# Altium schematic sheet Power.SchDoc(Power)
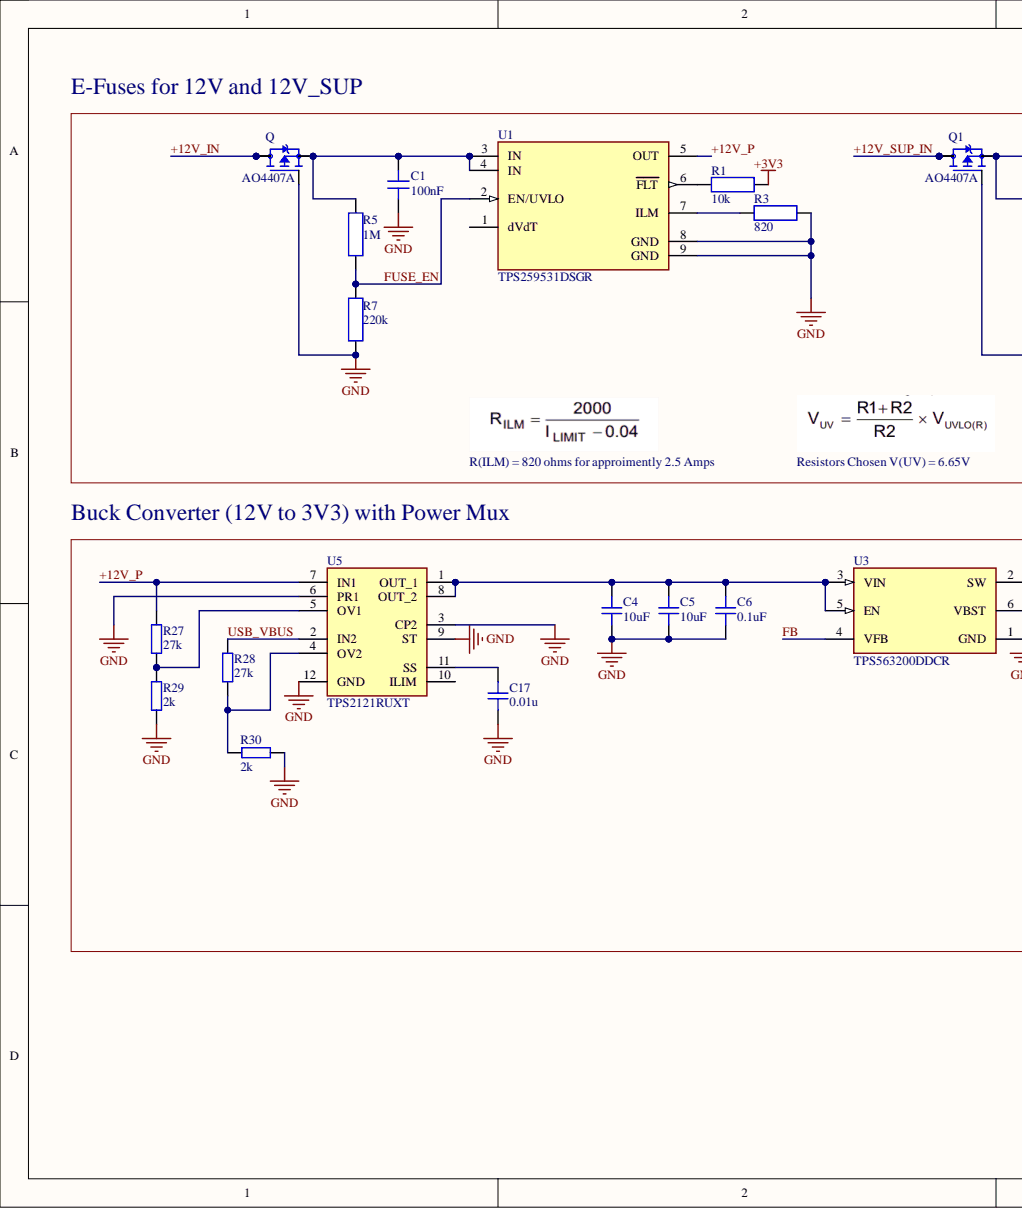
[diagram p0: Power.SchDoc, left]
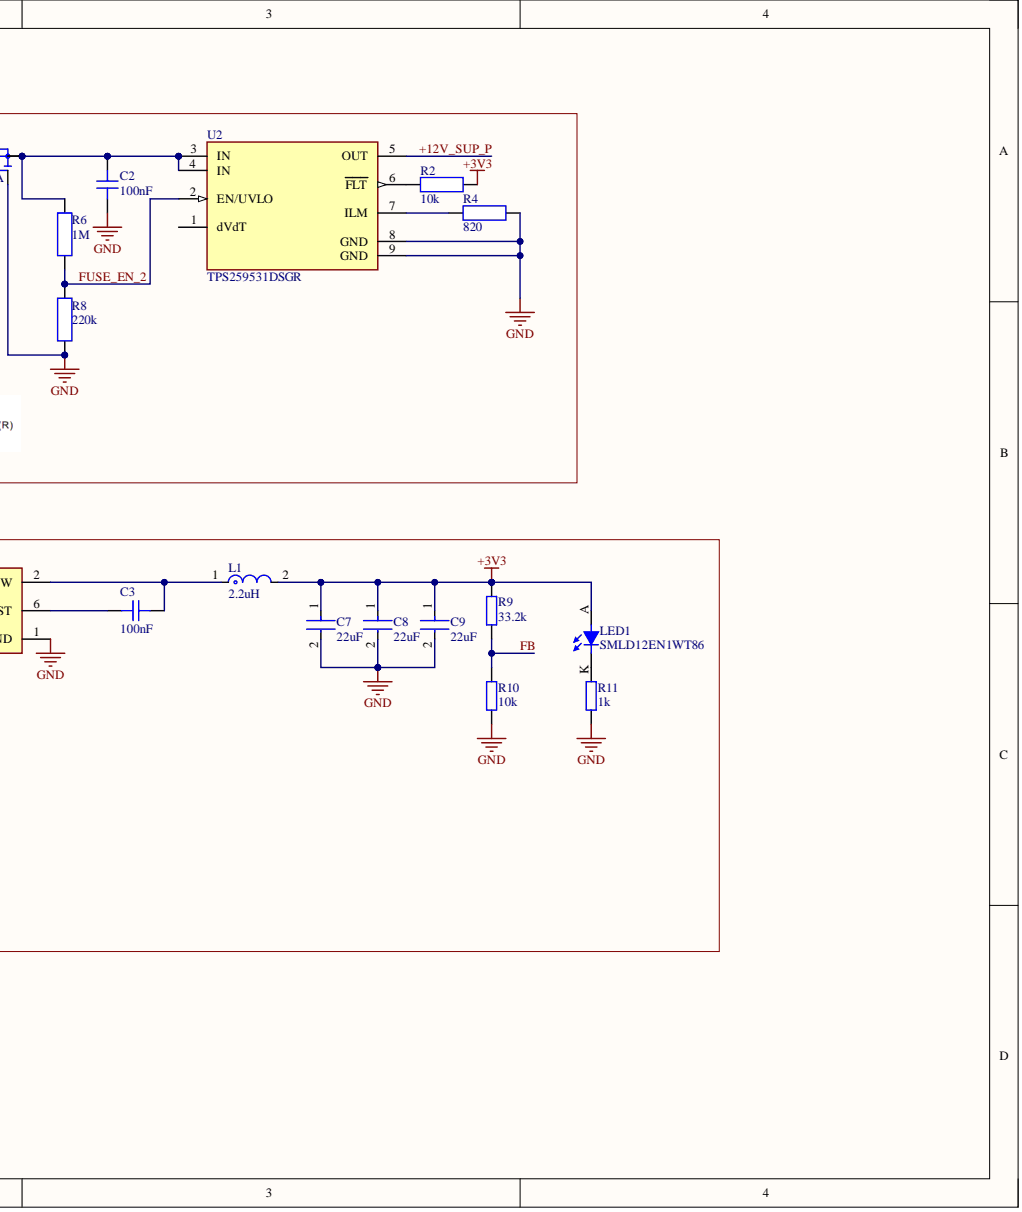
[diagram p1: Power.SchDoc, right]

## Parts
C1  value 100nF  (hidden comment: C0603C104K5RACTU)
C2  value 100nF  (hidden comment: C0603C104K5RACTU)
C3  100nF
C4  10uF
C5  10uF
C6  0.1uF
C7  22uF
C8  22uF
C9  22uF
C17  0.01u
L1  2.2uH
LED1  SMLD12EN1WT86
Q  AO4407A
Q1  AO4407A
R1  value 10k  (hidden comment: GENERIC_____0603)
R2  value 10k  (hidden comment: GENERIC_____0603)
R3  value 820  (hidden comment: GENERIC_____0603)
R4  value 820  (hidden comment: GENERIC_____0603)
R5  value 1M  (hidden comment: GENERIC_____0603)
R6  value 1M  (hidden comment: GENERIC_____0603)
R7  value 220k  (hidden comment: GENERIC_____0603)
R8  value 220k  (hidden comment: GENERIC_____0603)
R9  33.2k
R10  10k
R11  1k
R27  27k
R28  27k
R29  2k
R30  2k
U1  TPS259531DSGR
U2  TPS259531DSGR
U3  TPS563200DDCR
U5  TPS2121RUXT

## Nets
+3V3: C7.1 C8.1 C9.1 L1.2 LED1.A R1.1 R2.1 R9.2
GND: C1.1 C2.1 C4.1 C5.1 C6.1 C7.2 C8.2 C9.2 C17.2 Q.4 Q1.4 R3.2 R4.2 R7.1 R8.1 R10.1 R11.1 R29.1 R30.1 U1.8(GND) U1.9(GND) U2.8(GND) U2.9(GND) U3.1(GND) U5.3(CP2) U5.6(PR1) U5.9(ST) U5.12(GND)
+12V_IN: Q.5 Q.6 Q.7 Q.8
+12V_P: R27.2 U1.5(OUT) U5.7(IN1)
+12V_SUP_IN: Q1.5 Q1.6 Q1.7 Q1.8
+12V_SUP_P: U2.5(OUT)
FB: R9.1 R10.2 U3.4(VFB)
FUSE_EN: R5.1 R7.2 U1.2(EN/UVLO)
FUSE_EN_2: R6.1 R8.2 U2.2(EN/UVLO)
USB_VBUS: R28.2 U5.2(IN2)
NetC1_2: C1.2 Q.1 Q.2 Q.3 R5.2 U1.3(IN) U1.4(IN)
NetC2_2: C2.2 Q1.1 Q1.2 Q1.3 R6.2 U2.3(IN) U2.4(IN)
NetC3_1: C3.1 L1.1 U3.2(SW)
NetC3_2: C3.2 U3.6(VBST)
NetC4_2: C4.2 C5.2 C6.2 U3.3(VIN) U3.5(EN) U5.1(OUT_1) U5.8(OUT_2)
NetC17_1: C17.1 U5.11(SS)
NetLED1_K: LED1.K R11.2
NetR1_2: R1.2 U1.6(FLT)
NetR2_2: R2.2 U2.6(FLT)
NetR3_1: R3.1 U1.7(ILM)
NetR4_1: R4.1 U2.7(ILM)
NetR27_1: R27.1 R29.2 U5.5(OV1)
NetR28_1: R28.1 R30.2 U5.4(OV2)

Not connected: U1 1(dVdT); U2 1(dVdT); U5 10(ILIM)

## Library data of the parts
C1 C2 = CMP-001-00097-2: 0.1μF 50V X7R 0603 MLCC; Capacitor Type: Ceramic; Tolerance: 10%; Case/Package: 0603; Component Kind: Standard; Max Operating Temperature: 125°C; Min Operating Temperature: -55°C; Mounting Technology: Surface Mount; Pins: 2; RoHS Compliant: Yes; Voltage Rating: 50V; Library Name: Solar Gators
C3-C6 C17 = CAP_0603: Capacitor SMD 0603; Component Kind: Standard; Library Name: Schematic-Lib-PhilsLab (1).SchLib
C7-C9 = CMP-08246-004858-1: Multilayer Ceramic Capacitors 22μF ±20% 6.3V X5R SMD 1206; Capacitance: 22uF; Case Code (Imperial): 1206; Case Code (Metric): 3216; Case/Package: 1206; Component Kind: Standard; Depth: 1.6mm; Dielectric: X5R; Dielectric Material: Ceramic; Height: 0.85mm; Lead Free: Lead Free; Length: 0.126inch; Library Name: Altium Content Vault; Max Operating Temperature: 85°C; Min Operating Temperature: -55°C; Mount: Surface Mount; Number of Pins: 2; Package Quantity: 1; Packaging: Cut Tape; REACH SVHC: No SVHC; Resistor Type: Ceramic; RoHS Compliant: Yes; Series: C; Termination: SMD/SMT; Thickness: 0.037inch; Tolerance: 20%; Voltage Rating: 6.3V; Voltage Rating (DC): 6.3V; Weight: 0.000571oz; Width: 0.063inch
L1 = CMP-11268-000170-1: Molded Power Inductor, Shielded, 1uH 20%, 7.9A, 21.3mOhm DCR Max, AEC-Q200, T/R; Component Kind: Standard; Library Name: Altium Content Vault
LED1 = CMP-007-00036-6: Green LED 0603; Manufacturer_Name: ROHM Semiconductor; Forward Current: 5mA; Case/Package: 0603; Component Kind: Standard; Datasheet Link: https://datasheet.datasheetarchive.com/originals/distributors/Datasheets_SAMA/8f824a900f8c9a143b1c3640e6aee7b7.pdf; Design Item ID: SMLD12EN1WT86; Height: 0.65mm; Manufacturer_Part_Number: SMLD12EN1WT86; Mouser Part Number: 755-SMLD12EN1WT86; Mouser Price/Stock: https://www.mouser.co.uk/ProductDetail/ROHM-Semiconductor/SMLD12EN1WT86?qs=qSfuJ%252Bfl%2Fd4fR%252B9yZydIwg%3D%3D; Source: SamacSys.SchLib; Library Name: Solar Gators
Q Q1 = CMP-053-000001-2: P-Channel 30V 12A Surface Mount 8-SOIC; MF: Alpha &; Availability: Unavailable; Component Kind: Standard; Component Type: Standard; Design Item ID: AO4407A; MP: AO4407A; Package: SOIC-8 Alpha &amp; Omega Semiconductor; Pin Count: 8; Price: None; SnapEDA_Link: https://www.snapeda.com/parts/AO4407A/Alpha%20%26%20Omega%20Semiconductor%20Inc./view-part/492696/?ref=snap; Source: SnapEDAsch.SchLib; Library Name: Solar Gators
R1-R8 = CMP-009-00241-3: Resistor 0603 Generic; Tolerance: 1%; Case/Package: 0603; Component Kind: Standard; Max Operating Temperature: 125°C; Min Operating Temperature: -55°C; RoHS Compliant: Yes; Library Name: Solar Gators
R9-R11 R27-R30 = RES_0603: Resistor SMD 0603; Component Kind: Standard; Library Name: Schematic-Lib-PhilsLab (1).SchLib
U1 U2 = CMP-04913-000052-1: IC PWR MGMT EFUSE 2.7-18V 8WSON; Accuracy: 7.5%; Number of Channels: 1; Output Current: 4A; Component Kind: Standard; Library Name: Altium Content Vault; Max Operating Temperature: 125°C; Min Operating Temperature: -40°C; Mount: Surface Mount; Packaging: Cut Tape; RoHS Compliant: Yes
U3 = CMP-04918-000155-1: IC REG BUCK ADJ 3A TSOT23-6; Max Input Voltage: 17V; Max Output Voltage: 7V; Min Input Voltage: 4.5V; Min Output Voltage: 760mV; Number of Outputs: 1; Output Configuration: Positive; Output Type: Adjustable; Quiescent Current: 190uA; Switching Frequency: 650kHz; Synchronous Rectifier: Yes; Case/Package: SOT-23-6; Component Kind: Standard; Contact Plating: Tin; Lead Free: Lead Free; Library Name: Altium Content Vault; Max Operating Temperature: 125°C; Max Output Current: 3A; Min Operating Temperature: -40°C; Mount: Surface Mount; Operating Supply Voltage: 17V; Output Current: 3A; Output Voltage: 7V; Packaging: Tape and Reel; Radiation Hardening: No
U5 = CMP-031-00038-2: 2.7-22V Power Mux; Manufacturer_Name: Texas Instruments; Arrow Part Number: TPS2121RUXT; Arrow Price/Stock: https://www.arrow.com/en/products/tps2121ruxt/texas-instruments?region=nac; Component Kind: Standard; Datasheet Link: https://www.arrow.com/en/products/tps2121ruxt/texas-instruments; Design Item ID: TPS2121RUXT; Height: 1mm; Manufacturer_Part_Number: TPS2121RUXT; Mouser Part Number: 595-TPS2121RUXT; Mouser Price/Stock: https://www.mouser.co.uk/ProductDetail/Texas-Instruments/TPS2121RUXT?qs=l7cgNqFNU1i6abJCbO5ulQ%3D%3D; Source: SamacSys.SchLib; Library Name: Solar Gators
